# Altium schematic sheet Sheet5.SchDoc(Sheet5)
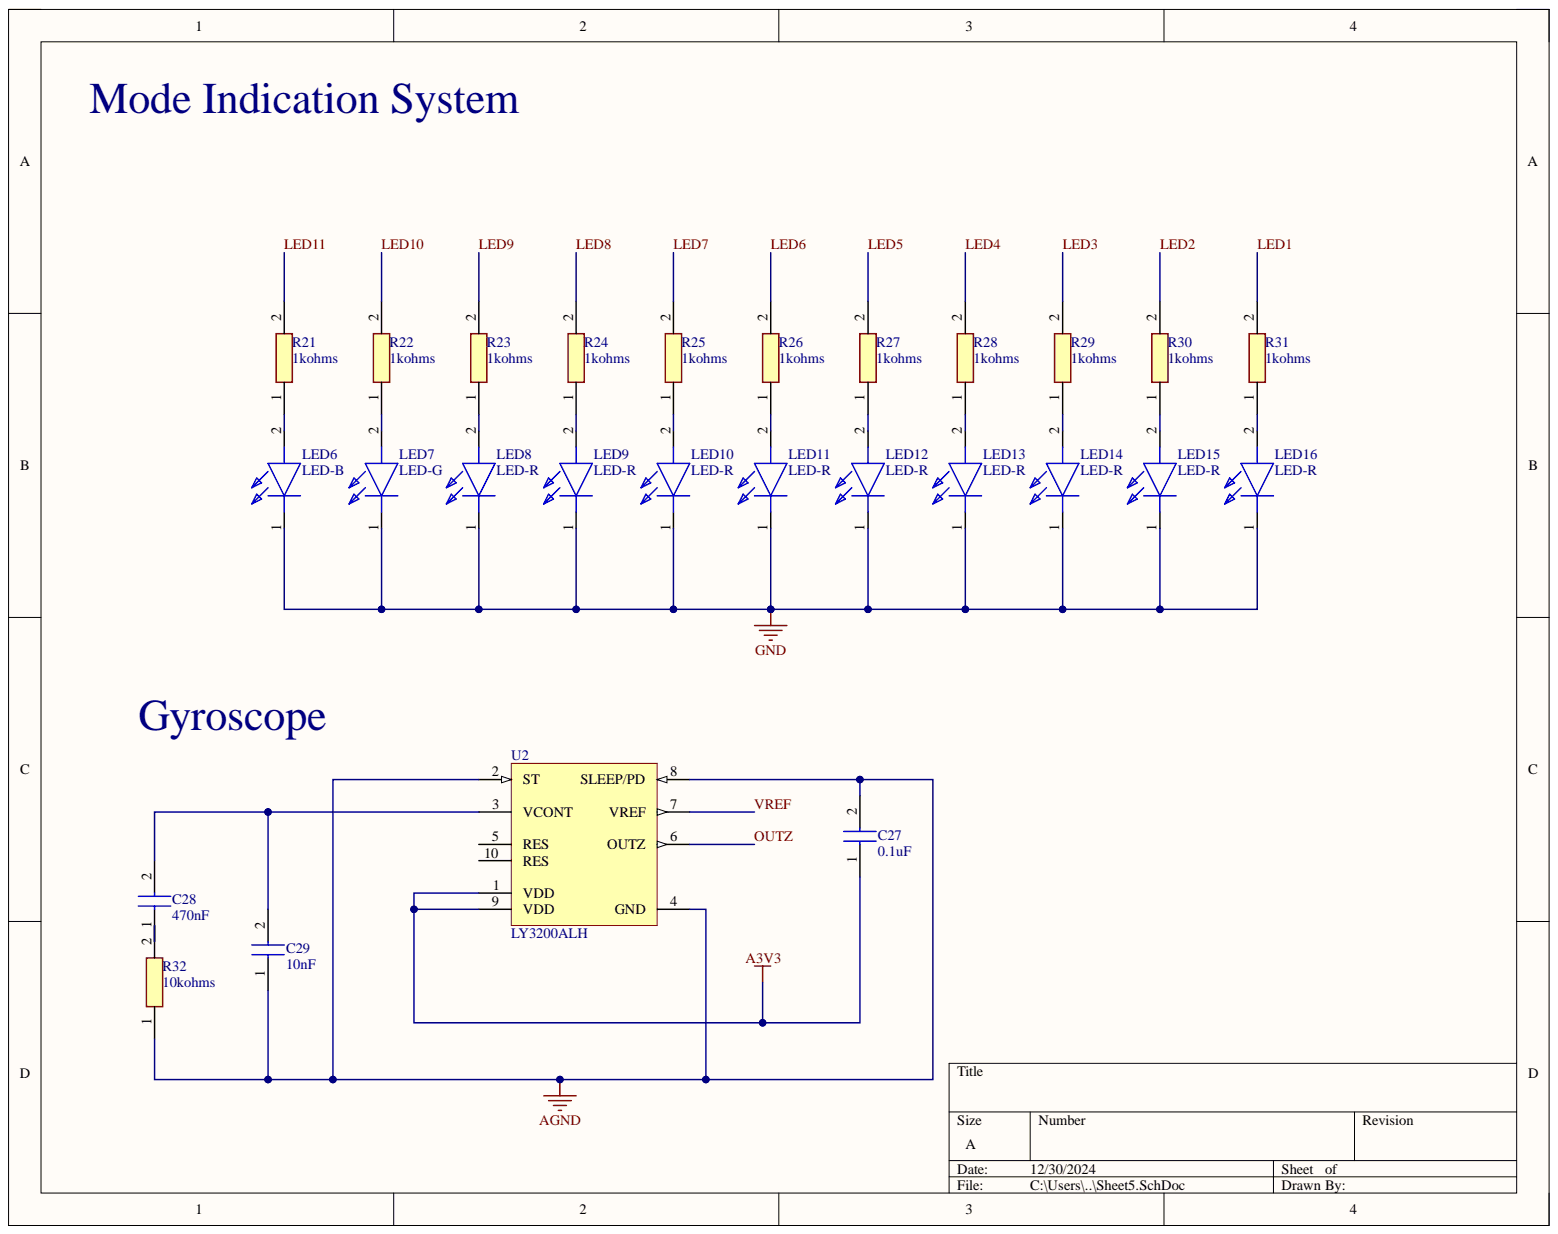
[diagram p0: Sheet5.SchDoc]

## Parts
C27  0.1uF  [CAPC1005X55N]
C28  470nF  [CAPC2012X140N]
C29  10nF  [CAPC2012X94N]
LED6  LED-B  [LEDC1608X55N]
LED7  LED-G  [LGL29KG2J124]
LED8  LED-R  [LEDC1608X45N]
LED9  LED-R  [LEDC1608X45N]
LED10  LED-R  [LEDC1608X45N]
LED11  LED-R  [LEDC1608X45N]
LED12  LED-R  [LEDC1608X45N]
LED13  LED-R  [LEDC1608X45N]
LED14  LED-R  [LEDC1608X45N]
LED15  LED-R  [LEDC1608X45N]
LED16  LED-R  [LEDC1608X45N]
R21  1kohms  [RESC1005X40N]
R22  1kohms  [RESC1005X40N]
R23  1kohms  [RESC1005X40N]
R24  1kohms  [RESC1005X40N]
R25  1kohms  [RESC1005X40N]
R26  1kohms  [RESC1005X40N]
R27  1kohms  [RESC1005X40N]
R28  1kohms  [RESC1005X40N]
R29  1kohms  [RESC1005X40N]
R30  1kohms  [RESC1005X40N]
R31  1kohms  [RESC1005X40N]
R32  10kohms  [RESC2013X51N]
U2  LY3200ALH  [LGA10-3X5X1_L]

## Nets
A3V3: C27.1 U2.1(VDD) U2.9(VDD)
AGND: C27.2 C29.1 R32.1 U2.2(ST) U2.4(GND) U2.8(SLEEP/PD)
GND: LED6.1 LED7.1 LED8.1 LED9.1 LED10.1 LED11.1 LED12.1 LED13.1 LED14.1 LED15.1 LED16.1
LED1: R31.2
LED2: R30.2
LED3: R29.2
LED4: R28.2
LED5: R27.2
LED6: R26.2
LED7: R25.2
LED8: R24.2
LED9: R23.2
LED10: R22.2
LED11: R21.2
OUTZ: U2.6(OUTZ)
VREF: U2.7(VREF)
NetC28_1: C28.1 R32.2
NetC28_2: C28.2 C29.2 U2.3(VCONT)
NetLED6_2: LED6.2 R21.1
NetLED7_2: LED7.2 R22.1
NetLED8_2: LED8.2 R23.1
NetLED9_2: LED9.2 R24.1
NetLED10_2: LED10.2 R25.1
NetLED11_2: LED11.2 R26.1
NetLED12_2: LED12.2 R27.1
NetLED13_2: LED13.2 R28.1
NetLED14_2: LED14.2 R29.1
NetLED15_2: LED15.2 R30.1
NetLED16_2: LED16.2 R31.1

Not connected: U2 5(RES) 10(RES)

## Library data of the parts
C27 = MBAST105SB7104KFNA01: 0.1uf SMD 25V cermaic; Manufacturer_Name: TAIYO YUDEN; Component Kind: Standard; Datasheet Link: https://ds.yuden.co.jp/TYCOMPAS/or/specSheet?pn=MBAST105SB7104KFNA01; Height: 0.55mm; Library Name: Schlib1.SchLib; Manufacturer_Part_Number: MBAST105SB7104KFNA01; Mouser Part Number: 963-BAST105SB7104KF; Mouser Price/Stock: https://www.mouser.co.uk/ProductDetail/TAIYO-YUDEN/MBAST105SB7104KFNA01?qs=tlsG%2FOw5FFj0FpJuqULGiw%3D%3D
C28 = 470nF: 470nF 20% 50V SMD 2.01mm; Manufacturer_Name: Kyocera AVX; Manufacturer_Part_Number: KAF21KR71H474MU; Component Kind: Standard; Datasheet Link: https://www.mouser.com/datasheet/2/40/AutoMLCCKAF-3218225.pdf; Height: 1.4mm; Library Name: Schlib1.SchLib; Mouser Part Number: 581-KAF21KR71H474MU; Mouser Price/Stock: https://www.mouser.co.uk/ProductDetail/KYOCERA-AVX/KAF21KR71H474MU?qs=Jm2GQyTW%2Fbiys8GWTnJ0Fg%3D%3D
C29 = 10nF: 10nF 5% 100VDC 2.01mm SMD cap; Manufacturer_Name: Kyocera AVX; Manufacturer_Part_Number: KAF21BR72A103JT; Component Kind: Standard; Datasheet Link: https://ro.mouser.com/datasheet/2/40/AutoMLCCKAF-3218225.pdf; Height: 0.94mm; Library Name: Schlib1.SchLib; Mouser Part Number: 581-KAF21BR72A103JT; Mouser Price/Stock: https://www.mouser.co.uk/ProductDetail/KYOCERA-AVX/KAF21BR72A103JT?qs=Jm2GQyTW%2Fbiu9dbnlAVfgQ%3D%3D
LED6 = LED-B: Single Color LEDs Blue 468nm 40mcd 20mA; Manufacturer_Name: Inolux; Manufacturer_Part_Number: IN-S63BT5B; Arrow Part Number: IN-S63BT5B; Arrow Price/Stock: https://www.arrow.com/en/products/in-s63bt5b/inolux-corporation?region=nac; Component Kind: Standard; Datasheet Link: https://www.inolux-corp.com/datasheet/SMDLED/Mono%20Color%20Top%20View/IN-S63BT%20Series_V1.0.pdf; Height: 0.55mm; Library Name: Schlib1.SchLib; Mouser Part Number: 743-S63BT5B; Mouser Price/Stock: https://www.mouser.co.uk/ProductDetail/Inolux/IN-S63BT5B?qs=u4fy%2FsgLU9OlGYjOpBGT9Q%3D%3D
LED7 = LED-G: Single Color LEDs Green, 570nm 12mcd, 20mA; Manufacturer_Name: ams OSRAM; Manufacturer_Part_Number: LG L29K-G2J1-24; Arrow Part Number: LG L29K-G2J1-24; Arrow Price/Stock: https://www.arrow.com/en/products/lg-l29k-g2j1-24/osram-opto-semiconductors?region=nac; Component Kind: Standard; Datasheet Link: https://look.ams-osram.com/m/19ee86b3ae0ee95b/original/LG-L29K.pdf; Height: 0.67mm; Library Name: Schlib1.SchLib; Mouser Part Number: 720-LGL29KG2J124Z; Mouser Price/Stock: https://www.mouser.co.uk/ProductDetail/ams-OSRAM/LG-L29K-G2J1-24?qs=%252BEew9%252B0nqrDT522vbrqDjw%3D%3D
LED8-LED16 = LED-R: RED - LED 5mA; Manufacturer_Name: Lite-On; Manufacturer_Part_Number: LTST-C193KRKT-5A; Arrow Part Number: LTST-C193KRKT-5A; Arrow Price/Stock: https://www.arrow.com/en/products/ltst-c193krkt-5a/lite-on-technology?utm_currency=USD&region=nac; Component Kind: Standard; Datasheet Link: http://uk.rs-online.com/web/p/products/1689748; Height: 0.45mm; Library Name: Schlib1.SchLib; Mouser Part Number: 859-LTSTC193KRKT5A; Mouser Price/Stock: https://www.mouser.co.uk/ProductDetail/Lite-On/LTST-C193KRKT-5A?qs=xIkB7y1OTor17kZSHyF5tQ%3D%3D
R21-R31 = 1kohms: 1kohms SMD thin film resistor 50V 1/16W; Manufacturer_Name: Vishay; Manufacturer_Part_Number: TNPU04021K00BZEP00; Arrow Part Number: TNPU04021K00BZEP00; Arrow Price/Stock: https://www.arrow.com/en/products/tnpu04021k00bzep00/vishay?region=nac; Component Kind: Standard; Datasheet Link: https://www.vishay.com/docs/28779/tnpue3.pdf; Height: 0.4mm; Library Name: Schlib1.SchLib; Mouser Part Number: 71-TNPU04021K00BZEP0; Mouser Price/Stock: https://www.mouser.co.uk/ProductDetail/Vishay-Draloric/TNPU04021K00BZEP00?qs=Cb2nCFKsA8qoR7PlcPQHOQ%3D%3D
R32 = 10kohms: 10kohms 0.1% 200mW 100V thin SMD; Manufacturer_Name: Vishay; Manufacturer_Part_Number: PAT0805E1002BST1; Arrow Part Number: PAT0805E1002BST1; Arrow Price/Stock: https://www.arrow.com/en/products/pat0805e1002bst1/vishay; Component Kind: Standard; Datasheet Link: https://www.vishay.com/docs/60024/pat.pdf; Height: 0.508mm; Library Name: Schlib1.SchLib; Mouser Part Number: 71-PAT0805E1002BST1; Mouser Price/Stock: https://www.mouser.co.uk/ProductDetail/Vishay-Thin-Film/PAT0805E1002BST1?qs=Bakm8ERcljqTU%2F0tdgaFxA%3D%3D
U2 = LY3200ALH: MEMS motion sensor: high performance ± 2000 dps analog yaw-rate gyroscope, -40 to +85°C, LGA-10 (3x5x1), Tray; Component Kind: Standard; ComponentLink1Description: LY3200ALH Datasheet; ComponentLink1URL: http://www.st.com/internet/com/TECHNICAL_RESOURCES/TECHNICAL_LITERATURE/DATASHEET/CD00254118.pdf; ComponentLink2Description: LY3200ALH Web Page; ComponentLink2URL: http://www.st.com/internet/analog/product/248609.jsp; ComponentLink3Description: st.com Home; ComponentLink3URL: http://www.st.com/internet/com/home/home.jsp; DatasheetVersion: rev1, Oct-2009; Library Name: Schlib1.SchLib; PackageDescription: 10-Lead Land Grid Array Package, 5 x 3 x 1.1 mm Body, 1.27/1.382 mm Pitch; PackageReference: LGA10-3X5X1; PackageVersion: 2010; PartNumber: LY3200ALH
